# Altium schematic sheet Interface.SchDoc(Interface)
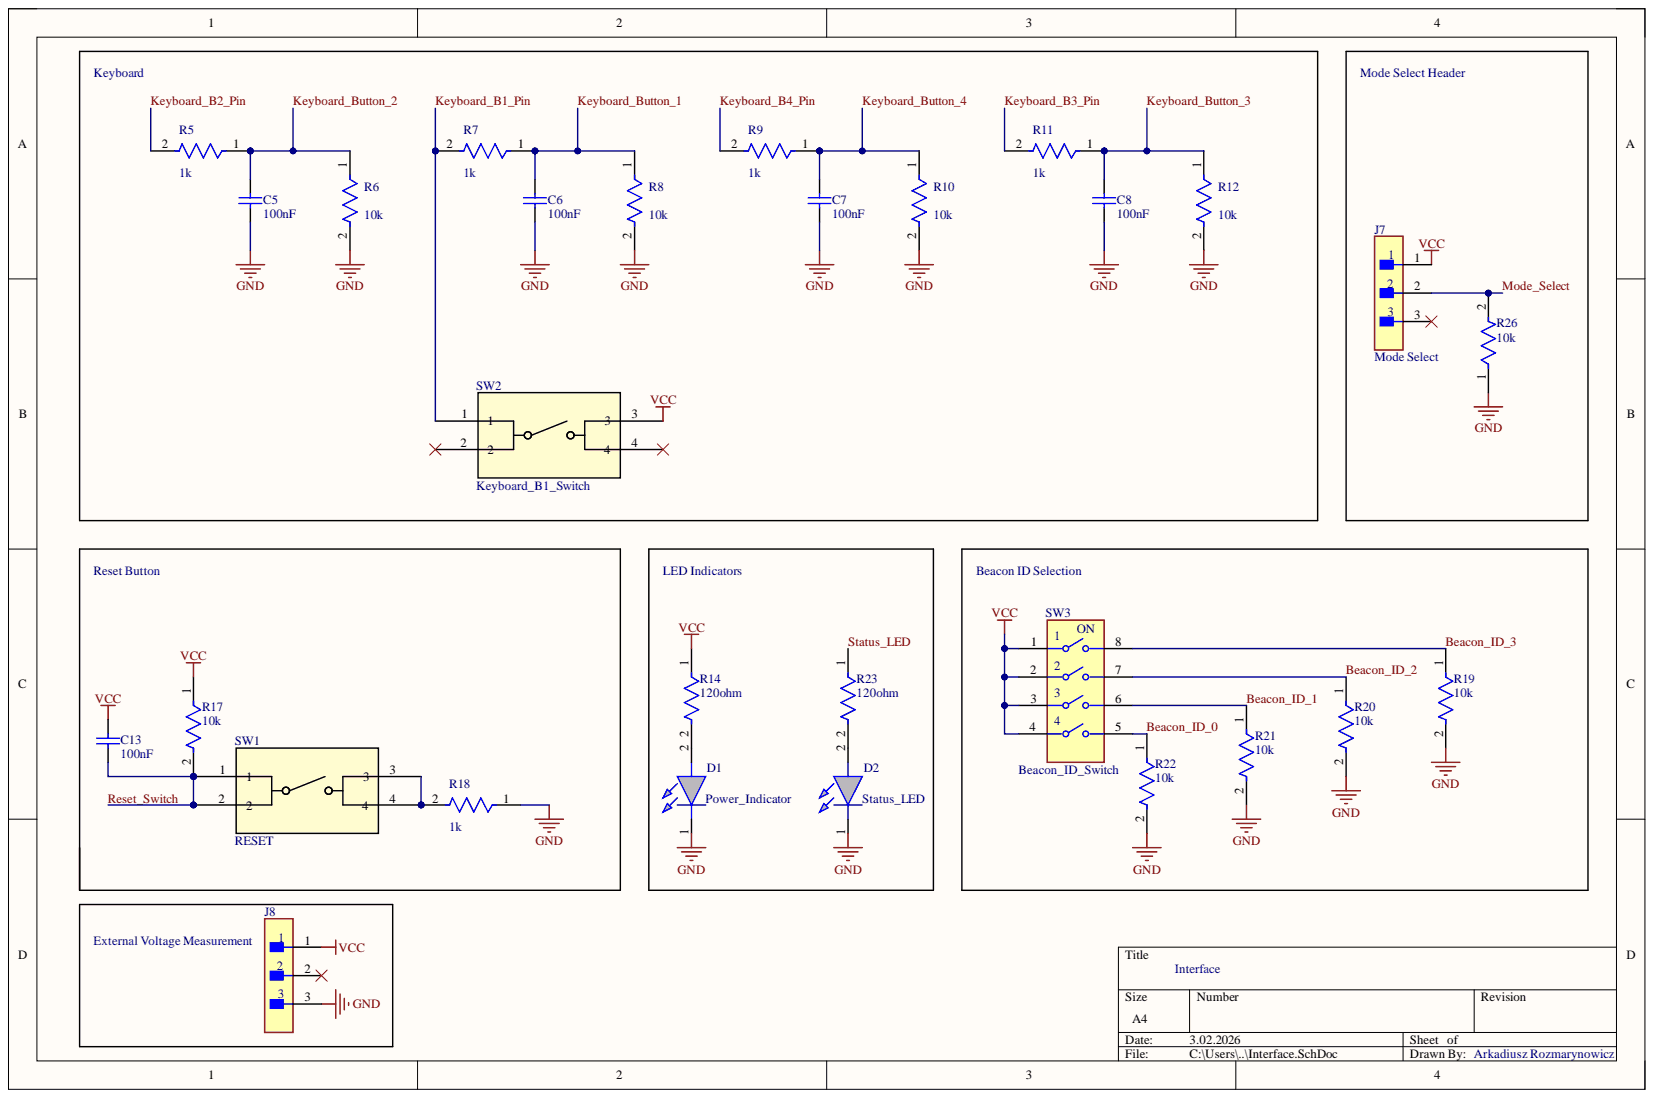
[diagram p0: Interface.SchDoc]

## Parts
C5  100nF  [CAPC2013X145X48NL20T23]
C6  100nF  [CAPC2013X145X48NL20T23]
C7  100nF  [CAPC2013X145X48NL20T23]
C8  100nF  [CAPC2013X145X48NL20T23]
C13  100nF  [CAPC2013X145X48NL20T23]
D1  Power_Indicator  [LEDC2012X40N]
D2  Status_LED  [LEDC2012X40N]
J7  Mode Select  [FP-TMM-103-03-T-S-MFG]
J8  External Voltage Measurement  [FP-TMM-103-03-T-S-MFG]
R5  1k  [FP-CRGCQ0805-IPC_C]
R6  10k  [FP-CRGCQ0805-IPC_C]
R7  1k  [FP-CRGCQ0805-IPC_C]
R8  10k  [FP-CRGCQ0805-IPC_C]
R9  1k  [FP-CRGCQ0805-IPC_C]
R10  10k  [FP-CRGCQ0805-IPC_C]
R11  1k  [FP-CRGCQ0805-IPC_C]
R12  10k  [FP-CRGCQ0805-IPC_C]
R14  120ohm  [FP-CRGCQ0805-IPC_C]
R17  10k  [FP-CRGCQ0805-IPC_C]
R18  1k  [FP-CRGCQ0805-IPC_C]
R19  10k  [FP-CRGCQ0805-IPC_C]
R20  10k  [FP-CRGCQ0805-IPC_C]
R21  10k  [FP-CRGCQ0805-IPC_C]
R22  10k  [FP-CRGCQ0805-IPC_C]
R23  120ohm  [FP-CRGCQ0805-IPC_C]
R26  10k  [FP-CRGCQ0805-IPC_C]
SW1  RESET  [SW4_FSM-5P23_TEC]
SW2  Keyboard_B1_Switch  [SW4_FSM-5P23_TEC]
SW3  Beacon_ID_Switch  [FP-A6S-4104-H-MFG]

## Nets
GND: C5.1 C6.1 C7.1 C8.1 D1.1 D2.1 J8.3 R6.2 R8.2 R10.2 R12.2 R18.1 R19.2 R20.2 R21.2 R22.2 R26.1
VCC: C13.2 J7.1 J8.1 R14.1 R17.1 SW2.3 SW3.1 SW3.2 SW3.3 SW3.4
Beacon_ID_0: R22.1 SW3.5
Beacon_ID_1: R21.1 SW3.6
Beacon_ID_2: R20.1 SW3.7
Beacon_ID_3: R19.1 SW3.8
Keyboard_B1_Pin: R7.2 SW2.1
Keyboard_B2_Pin: R5.2
Keyboard_B3_Pin: R11.2
Keyboard_B4_Pin: R9.2
Keyboard_Button_1: C6.2 R7.1 R8.1
Keyboard_Button_2: C5.2 R5.1 R6.1
Keyboard_Button_3: C8.2 R11.1 R12.1
Keyboard_Button_4: C7.2 R9.1 R10.1
Mode_Select: J7.2 R26.2
Reset_Switch: C13.1 R17.2 SW1.1 SW1.2
Status_LED: R23.1
NetD1_2: D1.2 R14.2
NetD2_2: D2.2 R23.2
NetR18_2: R18.2 SW1.3 SW1.4

Not connected: J7 3; J8 2; SW2 2 4

## Library data of the parts
C5-C8 C13 = CMP-2007-01705-1: Manufacturer: Vitramon; Part Number: VJ0805Y104KXAMR; Component Kind: Standard; Library Name: Altium Content Vault
D1 D2 = LS_R976: Red 0805 CHIPLED LS R976N-R102 Osram Opto LS R976, CHIPLED 0805 Series Red LED, 633 nm, 2012 (0805), Rectangle Lens SMD Package; Manufacturer_Name: ams OSRAM; Manufacturer_Part_Number: LS R976; Geometry.Height: 0.4mm; Arrow Part Number: LS R976; Arrow Price/Stock: https://www.arrow.com/en/products/lsr976/osram-opto-semiconductors?region=europe; Component Kind: Standard; Datasheet Link: http://uk.rs-online.com/web/p/products/4974804; Library Name: LS_R976.IntLib; Mouser Part Number: 720-LSR976D5178; Mouser Price/Stock: https://www.mouser.co.uk/ProductDetail/OSRAM-Opto-Semiconductors/LS-R976?qs=OIr7YF%252B2cWVTfzKl425Fkw%3D%3D
J7 J8 = CMP-02766-001695-1: CONN HEADER VERT 3POS 2MM; Gender: Male; Max Current Rating: 3.9A; Max Voltage Rating (AC): 500V; Number of Contacts: 3; Color: Black; Component Kind: Standard; Connector Type: Header; Contact Finish - Mating: TIN; Contact Material: Bronze; Contact Mating Length: 0.157inch; Contact Plating: Tin; Contact Shape: Square; Contact Type: Male Pin; Depth: 1.97mm; Fastening Type: Pull; Housing Color: Black; Housing Material: Polymer; Insulation Color: Black; Insulation Material: Polymer; Lead Free: Lead Free; Length: 6mm; Library Name: Altium Content Vault; Max Operating Temperature: 105°C; Min Operating Temperature: -55°C; Mount: Through Hole; Number of Positions: 3; Number of Rows: 1; Orientation: Straight; Package Quantity: 1; Packaging: Bulk; Pitch: 2mm; Radiation Hardening: No; REACH SVHC: No SVHC; RoHS Compliant: Yes; Series: TMM; Termination: Solder
R5 R7 R9 R11 R18 = CMP-03211-009742-1: CRGCQ0805 100KΩ 0.125W ±100ppm/°C 5% 150V; Manufacturer: TE Connectivity; Manufacturer Part Number: CRGCQ0805J100K; Tolerance: 0.05; Ambient Temperature Range High: +70°C; Automotive: Yes; Automotive Grade: Grade 1142; Category: Res; Component Kind: Standard; ComponentLink2Description: Datasheet; ComponentLink2URL: https://www.te.com/commerce/DocumentDelivery/DDEController?Action=showdoc&DocId=Data+Sheet%7F1773204-3%7F1%7Fpdf%7FEnglish%7FENG_DS_1773204-3_1.pdf%7F4-2176341-1151; Composition: Thick Film; Device Class L1: Passive Components; Device Class L2: Resistors; Device Class L3: Chip SMD Resistors; Height: 0.65mm; Ipc Land Pattern Name: RESC200125X55; Lead Free: Yes; Library Name: Altium Content Vault; Octopart: https://octopart.com/crgcq0805j100k-te+connectivity-91018199; Package: CRGCQ0805; Power Rating: 0.125W; Resistance: 1k; RoHS: TRUE; Series: CRGCQ; Temperature: -55°C to +155°C; Temperature Coefficient: ±100ppm/°C; Temperature Range High: +155°C; Temperature Range Low: -55°C; Value: 1k; Voltage Rating: 150V
R6 R8 R10 R12 R17 R19-R22 R26 = CMP-03211-009742-1: CRGCQ0805 10KΩ 0.125W ±100ppm/°C 5% 150V; Manufacturer: TE Connectivity; Manufacturer Part Number: CRGCQ0805J100K; Tolerance: 0.05; Ambient Temperature Range High: +70°C; Automotive: Yes; Automotive Grade: Grade 1142; Category: Res; Component Kind: Standard; ComponentLink2Description: Datasheet; ComponentLink2URL: https://www.te.com/commerce/DocumentDelivery/DDEController?Action=showdoc&DocId=Data+Sheet%7F1773204-3%7F1%7Fpdf%7FEnglish%7FENG_DS_1773204-3_1.pdf%7F4-2176341-1151; Composition: Thick Film; Device Class L1: Passive Components; Device Class L2: Resistors; Device Class L3: Chip SMD Resistors; Height: 0.65mm; Ipc Land Pattern Name: RESC200125X55; Lead Free: Yes; Library Name: Altium Content Vault; Octopart: https://octopart.com/crgcq0805j100k-te+connectivity-91018199; Package: CRGCQ0805; Power Rating: 0.125W; Resistance: 10k; RoHS: TRUE; Series: CRGCQ; Temperature: -55°C to +155°C; Temperature Coefficient: ±100ppm/°C; Temperature Range High: +155°C; Temperature Range Low: -55°C; Value: 10k; Voltage Rating: 150V
R14 R23 = CMP-03211-009742-1: CRGCQ0805 100KΩ 0.125W ±100ppm/°C 5% 150V; Manufacturer: TE Connectivity; Manufacturer Part Number: CRGCQ0805J100K; Resistance: 120; Tolerance: 0.05; Value: 120; Ambient Temperature Range High: +70°C; Automotive: Yes; Automotive Grade: Grade 1142; Category: Res; Component Kind: Standard; ComponentLink2Description: Datasheet; ComponentLink2URL: https://www.te.com/commerce/DocumentDelivery/DDEController?Action=showdoc&DocId=Data+Sheet%7F1773204-3%7F1%7Fpdf%7FEnglish%7FENG_DS_1773204-3_1.pdf%7F4-2176341-1151; Composition: Thick Film; Device Class L1: Passive Components; Device Class L2: Resistors; Device Class L3: Chip SMD Resistors; Height: 0.65mm; Ipc Land Pattern Name: RESC200125X55; Lead Free: Yes; Library Name: Altium Content Vault; Octopart: https://octopart.com/crgcq0805j100k-te+connectivity-91018199; Package: CRGCQ0805; Power Rating: 0.125W; RoHS: TRUE; Series: CRGCQ; Temperature: -55°C to +155°C; Temperature Coefficient: ±100ppm/°C; Temperature Range High: +155°C; Temperature Range Low: -55°C; Voltage Rating: 150V
SW1 SW2 = 1571563-4: FSM4JSMAATR=TACTSWITCH,SMT,T&R; Manufacturer_Name: TE Connectivity; Component Kind: Standard; Library Name: FSM4JSMAATR.IntLib; Manufacturer_Part_Number: 1571563-4; RefDes: RefDes; Type: Type; Copyright: Copyright (C) 2025 Ultra Librarian. All rights reserved.
SW3 = CMP-08827-000006-1: SWITCH SLIDE DIP SPST 25MA 24V; Manufacturer: Omron Electronics Inc-EMC Div; Manufacturer Part Number: A6S-4104-H; Contact Resistance: 200mΩ; Electromechanical Life: 1000Cycles; Number Of Circuits: 4; Standoff Height: 0.7mm; Ambient Temperature Range High: +70°C; Ambient Temperature Range Low: -20°C; Automotive: No; Category: Switch; Component Kind: Standard; ComponentLink2Description: Datasheet; ComponentLink2URL: https://omronfs.omron.com/en_US/ecb/products/pdf/en-a6s.pdf; Contact Current Rating: 25mA; Device Class L1: Electromechanical; Device Class L2: Switches; Device Class L3: DIP Switches; Digikey Description: SWITCH SLIDE DIP SPST 25MA 24V; Height: 4.5mm; Lead Free: Yes; Library Name: Altium Content Vault; Mount: Surface Mount; Mouser Description: DIP Switches / SIP Switches Dip Switch; Octopart: https://octopart.com/a6s-4104-h-omron-1480027; Package: 0; RoHS: TRUE; Temperature: -20°C to +70°C; Temperature Range High: +70°C; Temperature Range Low: -20°C; Throw Configuration: SPST; Voltage Rating Dc: 24V
